# Altium schematic sheet eRatPlatform_TopShieldsConnectors.SchDoc
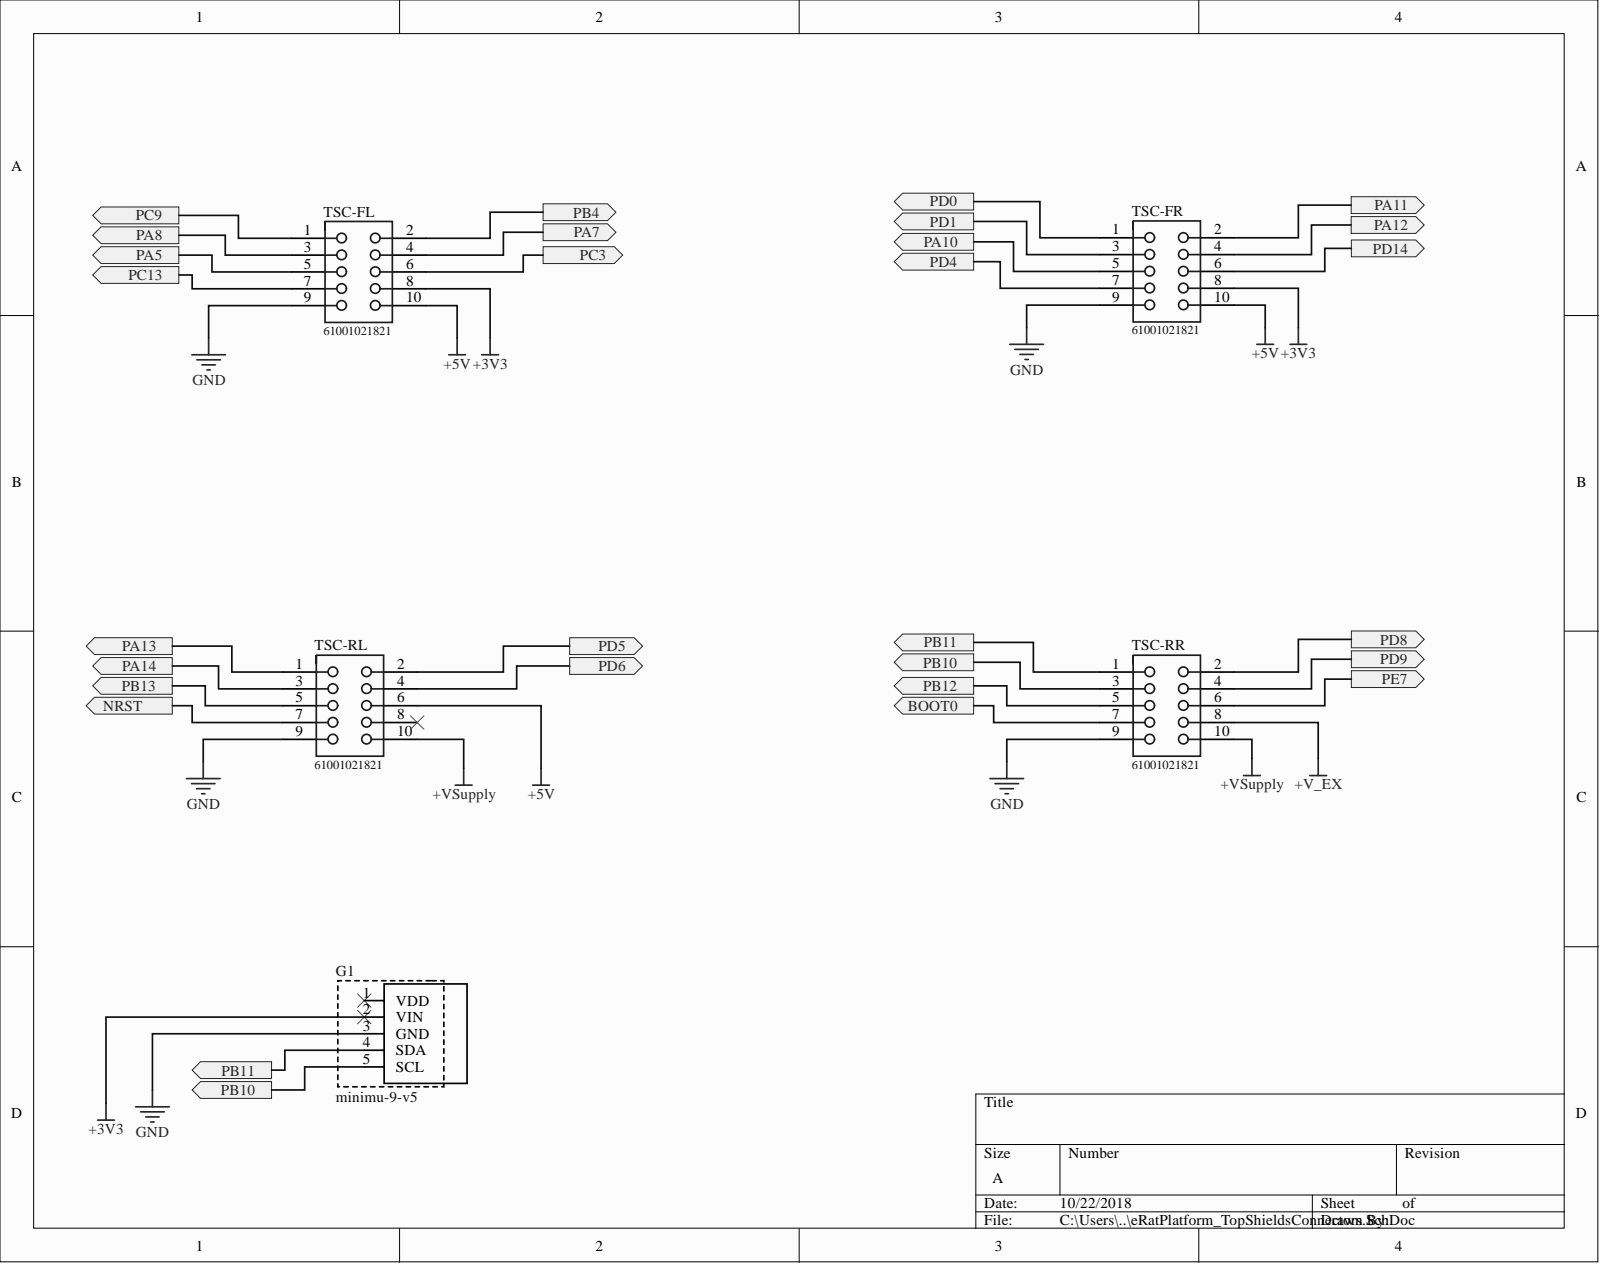
[diagram p0: eRatPlatform_TopShieldsConnectors.SchDoc]

## Parts
G1  minimu-9-v5  [minimu-9-v5]
TSC-FL  61001021821  [61001021821]
TSC-FR  61001021821  [61001021821]
TSC-RL  61001021821  [61001021821]
TSC-RR  61001021821  [61001021821]

## Ports
BOOT0, NRST, PA5, PA7, PA8, PA10, PA11, PA12, PA13, PA14, PB4, PB10, PB11, PB12, PB13, PC3, PC9, PC13, PD0, PD1, PD4, PD5, PD6, PD8, PD9, PD14, PE7

## Nets
+3V3: G1.2(VIN) TSC-FL.8 TSC-FR.8
+5V: TSC-FL.10 TSC-FR.10 TSC-RL.6
+V_EX: TSC-RR.8
+VSupply: TSC-RL.10 TSC-RR.10
GND: G1.3(GND) TSC-FL.9 TSC-FR.9 TSC-RL.9 TSC-RR.9
BOOT0: TSC-RR.7 ; port BOOT0
NRST: TSC-RL.7 ; port NRST
PA5: TSC-FL.5 ; port PA5
PA7: TSC-FL.4 ; port PA7
PA8: TSC-FL.3 ; port PA8
PA10: TSC-FR.5 ; port PA10
PA11: TSC-FR.2 ; port PA11
PA12: TSC-FR.4 ; port PA12
PA13: TSC-RL.1 ; port PA13
PA14: TSC-RL.3 ; port PA14
PB4: TSC-FL.2 ; port PB4
PB10: G1.5(SCL) TSC-RR.3 ; port PB10
PB11: G1.4(SDA) TSC-RR.1 ; port PB11
PB12: TSC-RR.5 ; port PB12
PB13: TSC-RL.5 ; port PB13
PC3: TSC-FL.6 ; port PC3
PC9: TSC-FL.1 ; port PC9
PC13: TSC-FL.7 ; port PC13
PD0: TSC-FR.1 ; port PD0
PD1: TSC-FR.3 ; port PD1
PD4: TSC-FR.7 ; port PD4
PD5: TSC-RL.2 ; port PD5
PD6: TSC-RL.4 ; port PD6
PD8: TSC-RR.2 ; port PD8
PD9: TSC-RR.4 ; port PD9
PD14: TSC-FR.6 ; port PD14
PE7: TSC-RR.6 ; port PE7

Not connected: G1 1(VDD); TSC-RL 8

## Library data of the parts
TSC-FL TSC-FR TSC-RL TSC-RR = 61001021821: SMT Vertical Socket Header WR-PHD, Pitch 2.54 mm, Dual Row, 10 pins; Contact Resistance: 20 mOhm; Gender: Female; Pin Number: 10; Pitch: 2.54 mm; Rated Current: 3 A; Withstanding Voltage: 600 VAC; Working Voltage: 250 VAC; Component Kind: Standard; ComponentLink1Description: Manufacturer; ComponentLink1URL: http://www.we-online.de; ComponentLink2Description: Datasheet; ComponentLink2URL: https://katalog.we-online.de/em/datasheet/6100xx21821.pdf; Library Name: ALTIUM-WR-PHD_SOCKET_HEADER-rev18b.IntLib; Mounting Technology: SMT; Operating Temperature: -40 to 105 degC; Orientation: Vertical; Packaging: Tube; Part Number: 61001021821; Published Date: August 2018; Row Number: Dual; Publisher: Wurth Elektronik
G1 = minimu-9-v5: Component Kind: Standard; Library Name: minimu-9-v5.IntLib
